# Altium schematic sheet CPU.SchDoc(CPU)
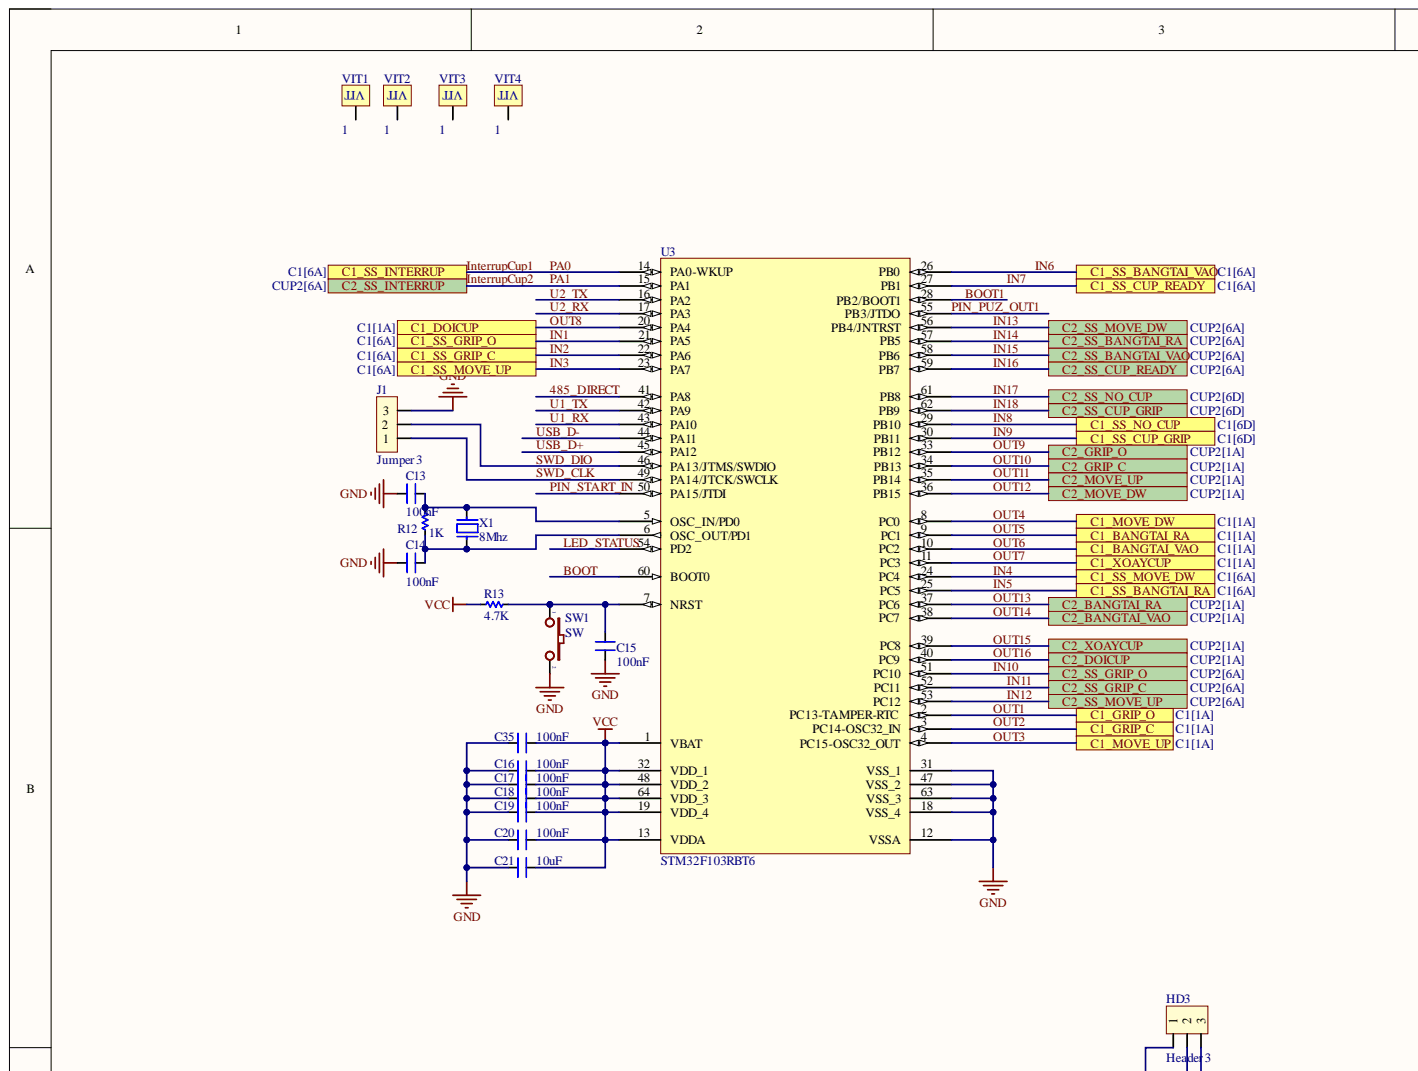
[diagram p0: CPU.SchDoc, top left]
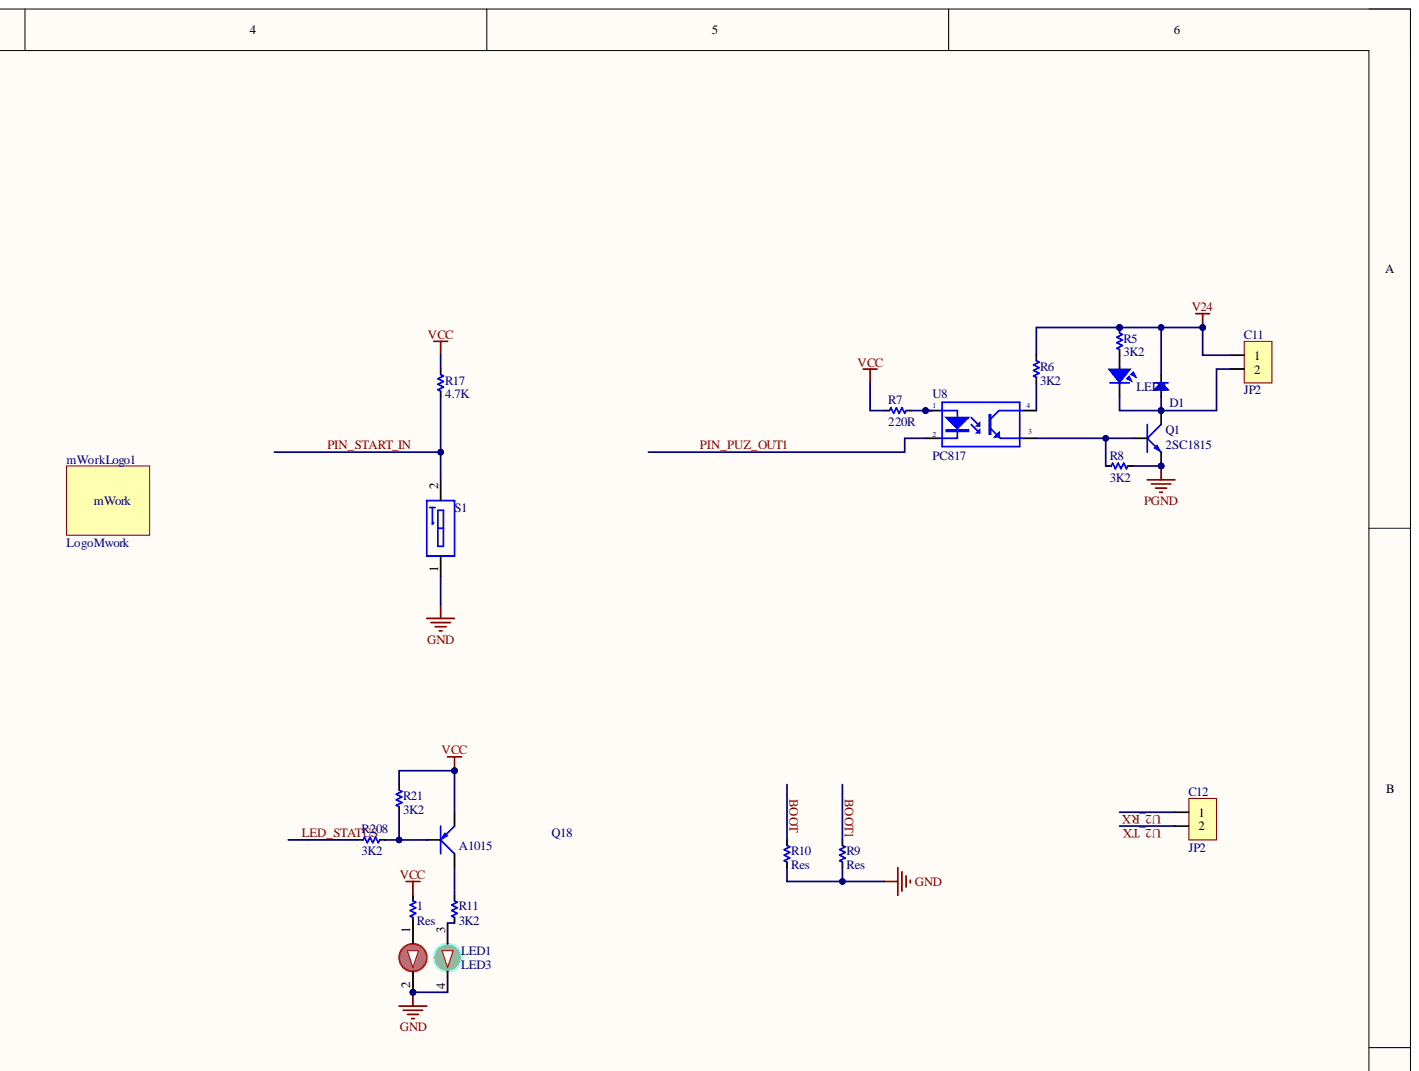
[diagram p1: CPU.SchDoc, top right]
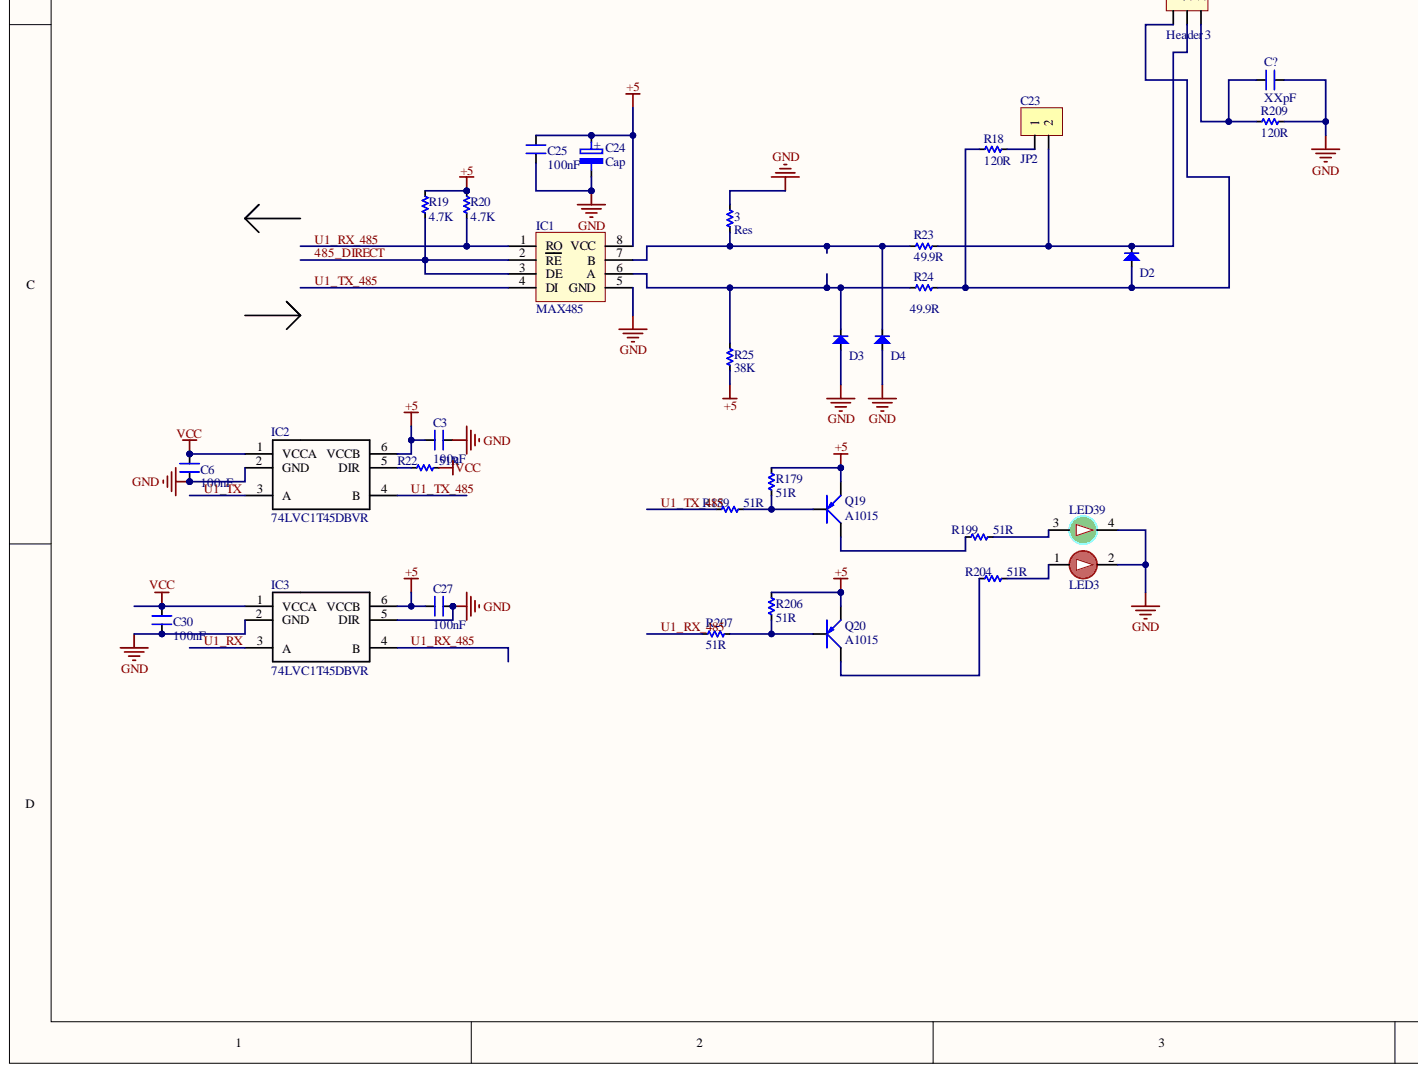
[diagram p2: CPU.SchDoc, bottom left]
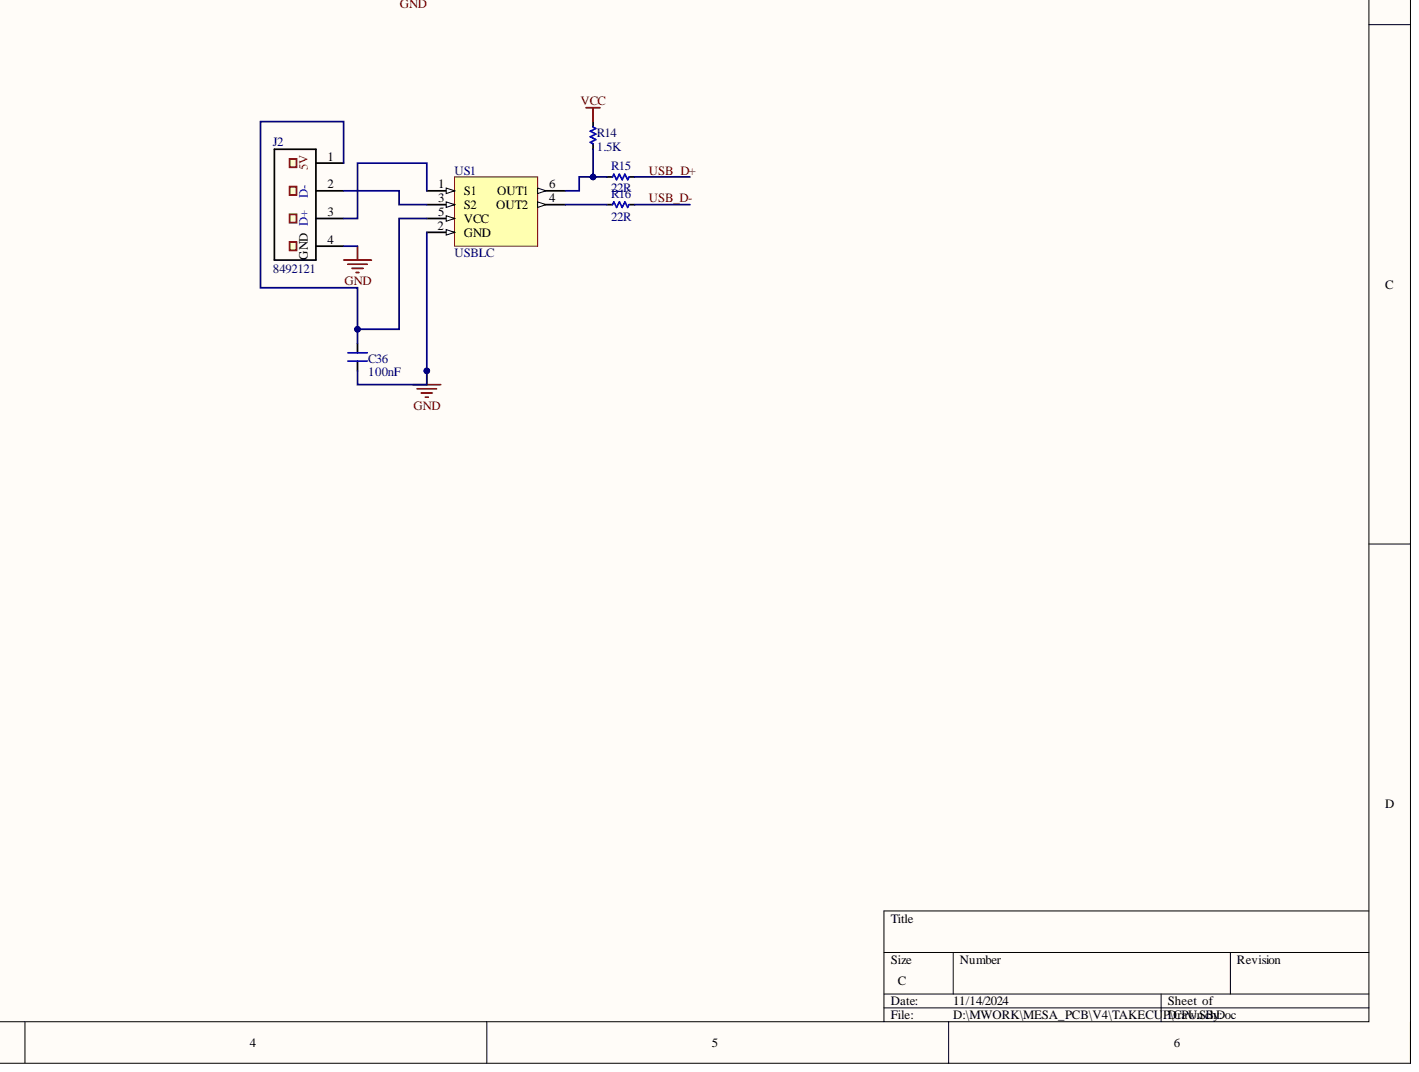
[diagram p3: CPU.SchDoc, bottom right]

## Parts
1  Res  [RES-SMD-0805]
3  Res  [RES-SMD-0805]
C3  100nF  [CN-SMD-0603]
C6  100nF  [CN-SMD-0603]
C11  JP2  [JP2-C1T]
C12  JP2  [JP2-C1T]
C13  100nF  [SM-CAP-0805]
C14  100nF  [SM-CAP-0805]
C15  100nF  [SM-CAP-0805]
C16  100nF  [SM-CAP-0805]
C17  100nF  [SM-CAP-0805]
C18  100nF  [SM-CAP-0805]
C19  100nF  [SM-CAP-0805]
C20  100nF  [SM-CAP-0805]
C21  10uF  [SM-CAP-0805]
C23  JP2  [JP2-CLOSED]
C24  Cap  [Cap_1206]
C25  100nF  [SM-CAP-0805]
C27  100nF  [CN-SMD-0603]
C30  100nF  [CN-SMD-0603]
C35  100nF  [SM-CAP-0805]
C36  100nF  [SM-CAP-0805]
C?  XXpF  [CN2-T]
D1  Diode  [DIO-SMD-106-HayDung]  (value not drawn)
D2  Diode  [DIO-SMD-106-HayDung]  (value not drawn)
D3  Diode  [DIO-SMD-106-HayDung]  (value not drawn)
D4  Diode  [DIO-SMD-106-HayDung]  (value not drawn)
HD3  Header 3  [HED3T]
IC1  MAX485  [SO8]
IC2  74LVC1T45DBVR  [SOT23-6-]
IC3  74LVC1T45DBVR  [SOT23-6-]
J1  Jumper 3  [JP3]
J2  8492121  [USB-C2]
LED1  LED3  [LedC]
LED2  LED  [LED-0805-Blue]
LED39  LED3  [LedC]
mWorkLogo1  LogoMwork  [LogoMwork15*6]
Q1  2SC1815  [SOT23-321]
Q18  A1015  [SOT23B]
Q19  A1015  [SOT23B]
Q20  A1015  [SOT23B]
R5  3K2  [RES-SMD-0805]
R6  3K2  [RES-SMD-0805]
R7  220R  [RES-SMD-0805]
R8  3K2  [RES-SMD-0805]
R9  Res  [RES-SMD-0805]
R10  Res  [RES-SMD-0805]
R11  3K2  [RES-SMD-0805]
R12  1K  [RES-SMD-0805]
R13  4.7K  [RES-SMD-0805]
R14  1.5K  [RES-SMD-0805]
R15  22R  [RES-SMD-0805]
R16  22R  [RES-SMD-0805]
R17  4.7K  [RES-SMD-0805]
R18  120R  [RES-SMD-0805]
R19  4.7K  [RES-SMD-0805]
R20  4.7K  [RES-SMD-0805]
R21  3K2  [RES-SMD-0805]
R22  51R  [RES-SMD-0603]
R23  49.9R  [RES-SMD-1206]
R24  49.9R  [RES-SMD-1206]
R25  38K  [RES-SMD-0805]
R179  51R  [RES-SMD-0805]
R189  51R  [RES-SMD-0805]
R199  51R  [RES-SMD-0805]
R204  51R  [RES-SMD-0805]
R206  51R  [RES-SMD-0805]
R207  51R  [RES-SMD-0805]
R208  3K2  [RES-SMD-0805]
R209  120R  [RES-SMD-0805]
S1  (no value)  [SW-DIP1]
SW1  SW  [SW2]
U3  STM32F103RBT6  [LQFP64_N]
U8  PC817  [DIP4]
US1  USBLC  [SOT23-6-]
VIT1  1  [VIT]
VIT2  1  [VIT]
VIT3  1  [VIT]
VIT4  1  [VIT]
X1  8Mhz  [XT2]

## Ports
C1_BANGTAI_RA, C1_BANGTAI_VAO, C1_DOICUP, C1_GRIP_C, C1_GRIP_O, C1_MOVE_DW, C1_MOVE_UP, C1_SS_BANGTAI_RA, C1_SS_BANGTAI_VAO, C1_SS_CUP_GRIP, C1_SS_CUP_READY, C1_SS_GRIP_C, C1_SS_GRIP_O, C1_SS_INTERRUP, C1_SS_MOVE_DW, C1_SS_MOVE_UP, C1_SS_NO_CUP, C1_XOAYCUP, C2_BANGTAI_RA, C2_BANGTAI_VAO, C2_DOICUP, C2_GRIP_C, C2_GRIP_O, C2_MOVE_DW, C2_MOVE_UP, C2_SS_BANGTAI_RA, C2_SS_BANGTAI_VAO, C2_SS_CUP_GRIP, C2_SS_CUP_READY, C2_SS_GRIP_C, C2_SS_GRIP_O, C2_SS_INTERRUP, C2_SS_MOVE_DW, C2_SS_MOVE_UP, C2_SS_NO_CUP, C2_XOAYCUP

## Nets
+5: C3.1 C24.1 C25.2 C27.2 IC1.8(VCC) IC2.6(VCCB) IC3.6(VCCB) Q19.1 Q20.1 R19.1 R20.1 R25.2 R179.1 R206.1
GND: 3.1 C3.2 C6.1 C13.2 C14.2 C15.1 C16.1 C17.1 C18.1 C19.1 C20.1 C21.1 C24.2 C25.1 C27.1 C30.1 C35.1 C36.1 C?.1 D3.1 D4.1 IC1.5(GND) IC2.2(GND) IC3.2(GND) IC3.5(DIR) J1.3 J2.4 LED1.2 LED1.4 LED39.2 LED39.4 R9.1 R10.1 R209.1 S1.1 SW1.2 U3.12(VSSA) U3.18(VSS_4) U3.31(VSS_1) U3.47(VSS_2) U3.63(VSS_3) US1.2(GND)
PGND: Q1.1 R8.1
V24: C11.1 D1.2 R5.1 R6.2
VCC: 1.1 C6.2 C16.2 C17.2 C18.2 C19.2 C20.2 C21.2 C30.2 C35.2 IC2.1(VCCA) IC3.1(VCCA) Q18.1 R7.1 R13.1 R14.2 R17.2 R21.2 R22.1 U3.1(VBAT) U3.13(VDDA) U3.19(VDD_4) U3.32(VDD_1) U3.48(VDD_2) U3.64(VDD_3)
485_DIRECT: IC1.2(RE) IC1.3(DE) R19.2 U3.41(PA8)
BOOT: R10.2 U3.60(BOOT0)
BOOT1: R9.2 U3.28(PB2/BOOT1)
IN1: U3.21(PA5) ; port C1_SS_GRIP_O
IN2: U3.22(PA6) ; port C1_SS_GRIP_C
IN3: U3.23(PA7) ; port C1_SS_MOVE_UP
IN4: U3.24(PC4) ; port C1_SS_MOVE_DW
IN5: U3.25(PC5) ; port C1_SS_BANGTAI_RA
IN6: U3.26(PB0) ; port C1_SS_BANGTAI_VAO
IN7: U3.27(PB1) ; port C1_SS_CUP_READY
IN8: U3.29(PB10) ; port C1_SS_NO_CUP
IN9: U3.30(PB11) ; port C1_SS_CUP_GRIP
IN10: U3.51(PC10) ; port C2_SS_GRIP_O
IN11: U3.52(PC11) ; port C2_SS_GRIP_C
IN12: U3.53(PC12) ; port C2_SS_MOVE_UP
IN13: U3.56(PB4/JNTRST) ; port C2_SS_MOVE_DW
IN14: U3.57(PB5) ; port C2_SS_BANGTAI_RA
IN15: U3.58(PB6) ; port C2_SS_BANGTAI_VAO
IN16: U3.59(PB7) ; port C2_SS_CUP_READY
IN17: U3.61(PB8) ; port C2_SS_NO_CUP
IN18: U3.62(PB9) ; port C2_SS_CUP_GRIP
InterrupCup1: U3.14(PA0-WKUP) ; port C1_SS_INTERRUP
InterrupCup2: U3.15(PA1) ; port C2_SS_INTERRUP
LED_STATUS: R208.2 U3.54(PD2)
OUT1: U3.2(PC13-TAMPER-RTC) ; port C1_GRIP_O
OUT2: U3.3(PC14-OSC32_IN) ; port C1_GRIP_C
OUT3: U3.4(PC15-OSC32_OUT) ; port C1_MOVE_UP
OUT4: U3.8(PC0) ; port C1_MOVE_DW
OUT5: U3.9(PC1) ; port C1_BANGTAI_RA
OUT6: U3.10(PC2) ; port C1_BANGTAI_VAO
OUT7: U3.11(PC3) ; port C1_XOAYCUP
OUT8: U3.20(PA4) ; port C1_DOICUP
OUT9: U3.33(PB12) ; port C2_GRIP_O
OUT10: U3.34(PB13) ; port C2_GRIP_C
OUT11: U3.35(PB14) ; port C2_MOVE_UP
OUT12: U3.36(PB15) ; port C2_MOVE_DW
OUT13: U3.37(PC6) ; port C2_BANGTAI_RA
OUT14: U3.38(PC7) ; port C2_BANGTAI_VAO
OUT15: U3.39(PC8) ; port C2_XOAYCUP
OUT16: U3.40(PC9) ; port C2_DOICUP
PIN_PUZ_OUT1: U3.55(PB3/JTDO) U8.2
PIN_START_IN: R17.1 S1.2 U3.50(PA15/JTDI)
SWD_CLK: J1.1 U3.49(PA14/JTCK/SWCLK)
SWD_DIO: J1.2 U3.46(PA13/JTMS/SWDIO)
U1_RX: IC3.3(A) U3.43(PA10)
U1_RX_485: IC1.1(RO) IC3.4(B) R20.2 R207.1
U1_TX: IC2.3(A) U3.42(PA9)
U1_TX_485: IC1.4(DI) IC2.4(B) R189.2
U2_RX: C12.1 U3.17(PA3)
U2_TX: C12.2 U3.16(PA2)
USB_D+: R15.2 U3.45(PA12)
USB_D-: R16.2 U3.44(PA11)
Net1_2: 1.2 LED1.1
Net3_2: 3.2 D4.2 IC1.7(B) R23.1
NetC11_2: C11.2 D1.1 LED2.2 Q1.2
NetC13_1: C13.1 R12.1 U3.5(OSC_IN/PD0) X1.2
NetC14_1: C14.1 R12.2 U3.6(OSC_OUT/PD1) X1.1
NetC15_2: C15.2 R13.2 SW1.1 U3.7(NRST)
NetC23_1: C23.1 R18.1
NetC23_2: C23.2 D2.2 HD3.2 R23.2
NetC36_2: C36.2 J2.1(5V) US1.5(VCC)
NetC?_2: C?.2 HD3.3 R209.2
NetD2_1: D2.1 HD3.1 R18.2 R24.2
NetD3_2: D3.2 IC1.6(A) R24.1 R25.1
NetIC2_5: IC2.5(DIR) R22.2
NetJ2_2: J2.2(D-) US1.3(S2)
NetJ2_3: J2.3(D+) US1.1(S1)
NetLED1_3: LED1.3 R11.2
NetLED2_1: LED2.1 R5.2
NetLED39_1: LED39.1 R204.1
NetLED39_3: LED39.3 R199.1
NetQ1_3: Q1.3 R8.2 U8.3
NetQ18_2: Q18.2 R11.1
NetQ18_3: Q18.3 R21.1 R208.1
NetQ19_2: Q19.2 R199.2
NetQ19_3: Q19.3 R179.2 R189.1
NetQ20_2: Q20.2 R204.2
NetQ20_3: Q20.3 R206.2 R207.2
NetR6_1: R6.1 U8.4
NetR7_2: R7.2 U8.1
NetR14_1: R14.1 R15.1 US1.6(OUT1)
NetR16_1: R16.1 US1.4(OUT2)

Not connected: VIT1 VIT1; VIT2 VIT1; VIT3 VIT1; VIT4 VIT1

## Library data of the parts
1 3 R9 R10 R12 R13 R17-R20 R22-R25 R179 R189 R199 R204 R206 R207 R209 = Res-SMD: Resistor; Manufacturer: Generic Components; Class: Passive; Component Kind: Standard; Published: 08/06/2000; Publisher: Altium Hobart Technology Centre
  R22 R179 R189 R199 R204 R206 R207: Library Name: LIBRARY.IntLib
  1 3 R9 R10 R12 R13 R17-R20 R23-R25 R209: Library Name: BanLinhKien.IntLib
C3 C6 C27 C30 C? = CN: Value: 33pF; Component Kind: Standard; Library Name: LIBRARY.IntLib
C11 C12 C23 = JP2: WR-PHD 2.54 mm Angled Socket Header; Manufacturer: W¨¹rth Elektronik eiSos GmbH; partNo: 613002143121; ComponentLink1 Description: Manufacturer; ComponentLink2 Description: Datasheet; NoPins: 02; Component Kind: Standard; ComponentLink1URL: http://www.we-online.com; ComponentLink2URL: http://katalog.we-online.de/em/datasheet/6130xx143121.pdf; Created Date: 29 March 2013; Created by: Karrer Zheng; Library Name: nhan.SchLib
C13-C21 C25 C35 C36 = CN: Designed by: VanDungEvn; Value: 0,1uF/300V; Component Kind: Standard; Library Name: syhaunguyen.IntLib
C24 = Cap_tan: Polarized Capacitor (Radial); Component Kind: Standard; Library Name: nhan.SchLib
D1-D4 = Diode: Default Diode; Code - JEDEC: DO-214-AB; Value: 1N5007; Component Kind: Standard; ComponentLink1Description: ES1J - STMBL; ComponentLink1URL: https://banlinhkien.vn/goods-8089-es1j-do214-diode-1a-600v-sma-es1j-.html; Published: 8-Jun-2000; Revision: July-2002: Re-released for DXP Platform.; Library Name: nhan.SchLib; Publisher: Altium Limited
HD3 = Hed3: Header 3 (Green); Component Kind: Standard; Value: Header 3; Library Name: syhaunguyen.IntLib
IC1 = MAX485: RS232 - RS485 (Same sn75176); Component Kind: Standard; Library Name: BanLinhKien.IntLib
IC2 IC3 = 74LVC1T45DBVR: SN74LVC1T45DBVR; Component Kind: Standard; ComponentLink1Description: Mua; ComponentLink1URL: https://www.thegioiic.com/sn74lvc1t45dbvr-ic-voltage-level-shifter-bus-transceiver-6-sot-23; Library Name: nhan.SchLib
J1 = JP3: Value: J3; Component Kind: Standard; Description: Jumper 3; Library Name: syhaunguyen.IntLib
J2 = USB-4: THT USB 2.0 A Connector WE-EPLE; Manufacturer: Würth Elektronik eiSos GmbH; Component Kind: Standard; ComponentLink1Description: Manufacturer; ComponentLink1URL: http://www.we-online.de; ComponentLink2Description: Datasheet; ComponentLink2URL: http://www.we-online.de/katalog/media/pdf/8492121.pdf; Created Date: OCT-2014; PartNo: 8492121; Created by: Christin Otto; Library Name: nhan.SchLib
LED1 LED39 = LED: LED 3mm; Component Kind: Standard; Library Name: nhan.SchLib
LED2 = LED SMD: Value: LED 3 - 5 ly 2 Colour; Component Kind: Standard; Library Name: LIBRARY.IntLib
Q1 = 2SC1815: NPN 600mW, ECB; Value: C1815; Component Kind: Standard; Library Name: LIBRARY.IntLib
Q18-Q20 = A1015: PNP 600mW, ECB; Component Kind: Standard; Value: A1015; Library Name: syhaunguyen.IntLib
R5-R8 R11 R14-R16 R21 R208 = R: Component Kind: Standard; Library Name: nhan.SchLib
S1 = SWDIP1: WS-DITV 2.54 mm small compact THT flat actuator with top tape; Manufacturer: Wurth Elektronik; Part No.: 418127160901; ComponentLink1 Description: Manufacturer; ComponentLink2 Description: Datasheet; Pole: 01; Component Kind: Standard; ComponentLink1URL: http://www.we-online.com; ComponentLink2URL: http://katalog.we-online.de/em/datasheet/4181271609xx.pdf; Created Date: Dec.2015; Library Name: Library.SchLib; Created by: Baojun Chen
SW1 = SW2: Switch button 2 pin; Value: SW chip 2 pin; Component Kind: Standard; Library Name: syhaunguyen.IntLib
U3 = STM32F103RBT6: STM32 ARM-based 32-bit MCU with 128 Kbytes Flash, 64-pin LQFP, Industrial Temperature; Component Kind: Standard; ComponentLink1Description: Manufacturer Link; ComponentLink1URL: www.st.com/stm32; ComponentLink2Description: Datasheet; ComponentLink2URL: http://www.st.com/stonline/products/literature/ds/13587.pdf; DatasheetVersion: rev6, Mar-2008; Library Name: ST Microcontroller 32-Bit STM32.IntLib; PackageDescription: 64-Pin Low-Profile Quad Flat Pack, 10 x 10 mm Body, 0.5 mm Pitch (LQFP64); PackageReference: LQFP64; PackageVersion: Mar-2008; Published: 30-May-2008; Publisher: Altium Limited
U8 = PC817: Single Opto; Component Kind: Standard; Library Name: LIBRARY.IntLib; Value: PC817
US1 = USBLC6-2S: Component Kind: Standard; ComponentLink1Description: Mua; ComponentLink1URL: http://robocon.vn/detail/ic81-ic-dan-ul26-usblc6-2sc6-sot-23-6-st-st-esd.html; Library Name: nhan.SchLib
VIT1-VIT4 = VIT: Oc Vit; Component Kind: Standard; Library Name: syhaunguyen.IntLib
X1 = XT2: Crystal, 32.768 KHz, -40 to 85 degC, 3.2 x 1.5 x 0.9 mm SMD; Value: 4Mhz; Component Kind: Standard; Library Name: BanLinhKien.IntLib
mWorkLogo1 = LogoMwork: Component Kind: Standard; Library Name: nhan.SchLib
